# Altium schematic sheet Speaker_Switches.SchDoc
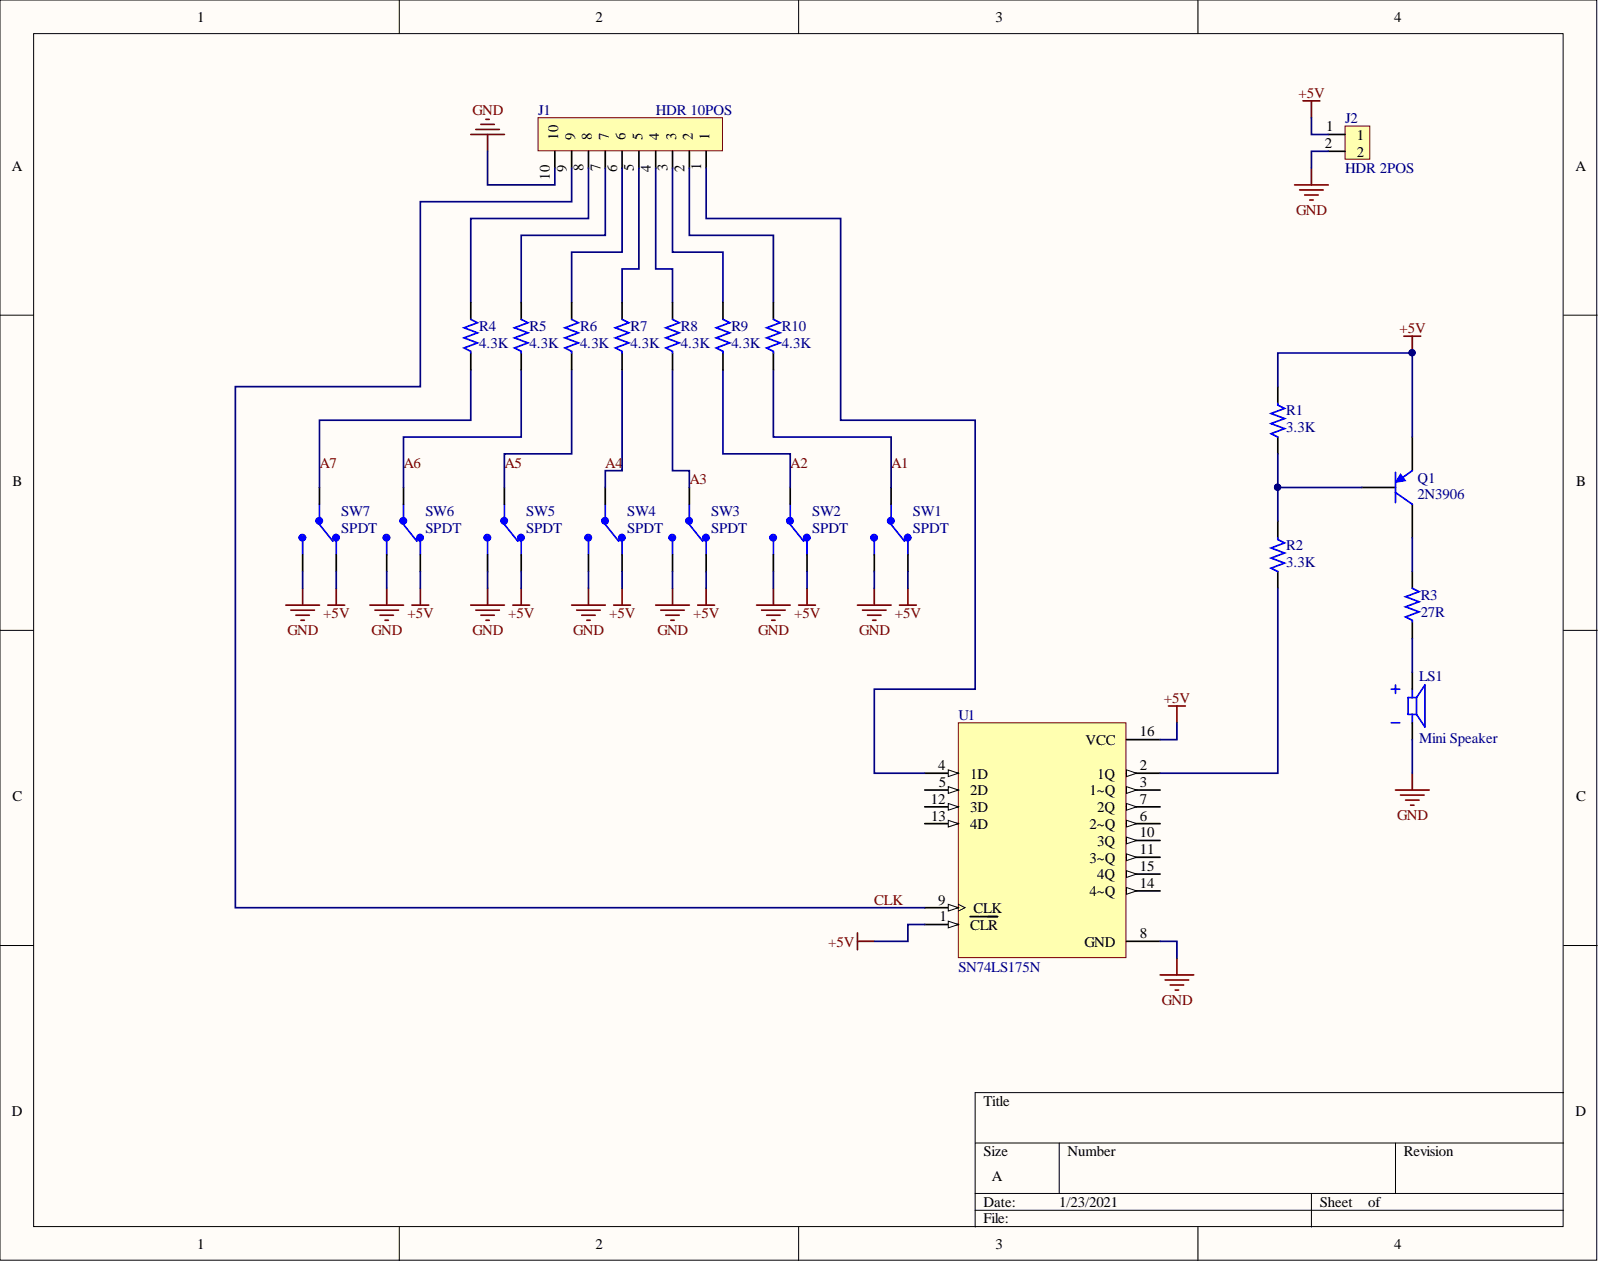
[diagram p0: Speaker_Switches.SchDoc]

## Parts
J1  HDR 10POS  [HDR 10 POS 2.54 FEMALE ST]
J2  HDR 2POS  [HDR 2 POS 2.54 Male ST]
LS1  Mini Speaker  [Speaker  Adafruit 8 Ohm 0.2W TH 1898 30mm 15mm]
Q1  2N3906  [TO-92A]
R1  3.3K  [Res TH 460mil 11.68mm]
R2  3.3K  [Res TH 460mil 11.68mm]
R3  27R  [Res TH 460mil 11.68mm]
R4  4.3K  [Res TH 460mil 11.68mm]
R5  4.3K  [Res TH 460mil 11.68mm]
R6  4.3K  [Res TH 460mil 11.68mm]
R7  4.3K  [Res TH 460mil 11.68mm]
R8  4.3K  [Res TH 460mil 11.68mm]
R9  4.3K  [Res TH 460mil 11.68mm]
R10  4.3K  [Res TH 460mil 11.68mm]
SW1  SPDT  [SW_1218_EWI]
SW2  SPDT  [SW_1218_EWI]
SW3  SPDT  [SW_1218_EWI]
SW4  SPDT  [SW_1218_EWI]
SW5  SPDT  [SW_1218_EWI]
SW6  SPDT  [SW_1218_EWI]
SW7  SPDT  [SW_1218_EWI]
U1  SN74LS175N  [DIP794W45P254L1969H508Q16]

## Nets
+5V: J2.1 Q1.1 R1.2 SW1.1 SW2.1 SW3.1 SW4.1 SW5.1 SW6.1 SW7.1 U1.1 U1.16
GND: J1.10 J2.2 LS1.N SW1.3 SW2.3 SW3.3 SW4.3 SW5.3 SW6.3 SW7.3 U1.8
A1: R10.2 SW1.2
A2: R9.2 SW2.2
A3: R8.2 SW3.2
A4: R7.2 SW4.2
A5: R6.2 SW5.2
A6: R5.2 SW6.2
A7: R4.2 SW7.2
CLK: J1.9 U1.9
NetJ1_1: J1.1 U1.4
NetJ1_2: J1.2 R10.1
NetJ1_3: J1.3 R9.1
NetJ1_4: J1.4 R8.1
NetJ1_5: J1.5 R7.1
NetJ1_6: J1.6 R6.1
NetJ1_7: J1.7 R5.1
NetJ1_8: J1.8 R4.1
NetLS1_P: LS1.P R3.2
NetQ1_2: Q1.2 R1.1 R2.2
NetQ1_3: Q1.3 R3.1
NetR2_1: R2.1 U1.2

Not connected: U1 3 5 6 7 10 11 12 13 14 15

## Library data of the parts
J1 = HDR 10 Pos 2.54mm female TH ST: Manufacturer Part Number 1: 90147-1110; Brand: Molex; Category 1: Headers & Wire Housings; Current Rating: 3 A; Description 1: Headers & Wire Housings C-Grid PCB Conn SR V onn SR Vt Tn-A 10Ckt; Mounting Angle: Vertical; Number of Positions: 10 Position; Voltage Rating: 350 V; Accessory Type: -; Component Kind: Standard; ComponentLink1Description: https://www.mouser.com/datasheet/2/276/1/0901471110_PCB_RECEPTACLES-176767.pdf; ComponentLink1URL: https://www.mouser.com/datasheet/2/276/1/0901471110_PCB_RECEPTACLES-176767.pdf; Contact Gender: Socket (Female); Contact Material: Tin; Contact Plating: Tin; Contact Type: -; Description: HDR 10 Pos 2.54mm female TH ST; Flammability Rating: UL 94 V-0; Housing Color: Black; Housing Gender: -; Housing Material: Polyester; Library Name: Schematic_Library.SchLib; Manufacturer 1: Molex; Maximum Operating Temperature: + 105 C; Minimum Operating Temperature: - 55 C; Minimum Order Quantity: 1; Mounting Style: Through Hole; Number of Rows: 1 Row; Packaging: Tube; Pitch: 2.54 mm; Pricing 1: 1=2.53, 10=2.06, 100=1.97, 250=1.78, 500=1.64, 1000=1.36, 2500=1.31, 5000=1.25 (USD); Product: Headers; Product Type: Headers & Wire Housings; Reeling: False; RoHS 1: RoHS Compliant; RoHS - Mouser: Y; Row Spacing: -; Series: 90147; Standard Pack Qty: 1760; Stock 1: 1869; Subcategory: Headers & Wire Housings; Supplier 1: Mouser; Supplier Part Number 1: 538-90147-1110; Termination Style: Through Hole; Tradename: C-Grid; Type: Socket
J2 = HDR 2 pos 2.54mm TH male Straigt: Manufacturer Part Number 1: 61300211121; Brand: Wurth Elektronik; Category 1: Headers & Wire Housings; Current Rating: 3 A; Description 1: Headers & Wire Housings WR-PHD 2.54mm 2Pin THT Header; Insulation Resistance: 1 GOhms; Latching Type: Unlatched; Mating Post Length: 6 mm; Mounting Angle: Straight; Number of Positions: 2 Position; Voltage Rating: 250 V; Accessory Type: -; Application: Wire to Board; Component Kind: Standard; ComponentLink1Description: https://www.mouser.com/datasheet/2/445/61300211121-1717910.pdf; ComponentLink1URL: https://www.mouser.com/datasheet/2/445/61300211121-1717910.pdf; Contact Gender: Pin (Male); Contact Material: Copper Alloy; Contact Plating: Gold; Contact Type: -; Description: HDR 2 pos 2.54mm TH male Straigt; Flammability Rating: UL 94 V-0; Housing Color: Black; Housing Gender: -; Housing Material: Polybutylene Terephthalate (PBT); Library Name: Schematic_Library.SchLib; Manufacturer 1: Wurth Elektronik; Maximum Operating Temperature: + 125 C; Minimum Operating Temperature: - 40 C; Minimum Order Quantity: 1; Mounting Style: Straight Pin; Number of Rows: 1 Row; Packaging: Bulk; Pitch: 2.54 mm; Pricing 1: 1=0.120, 10=0.118, 25=0.116, 50=0.113, 100=0.106, 250=0.104, 500=0.090, 1000=0.089 (USD); Product: Headers; Product Type: Headers & Wire Housings; Reeling: False; RoHS 1: RoHS Compliant; RoHS - Mouser: Y; Row Spacing: -; Series: WR-PHD; Standard Pack Qty: 1000; Stock 1: 32934; Subcategory: Headers & Wire Housings; Supplier 1: Mouser; Supplier Part Number 1: 710-61300211121; Termination Post Length: 3 mm; Termination Style: Through Hole; Type: Pin Strip
LS1 = Speaker - 8 Ohm 0.2W Breadboard-Friendly PCB Mount Mini Speaker TH: Breadboard-Friendly PCB Mount Mini Speaker - 8 Ohm 0.2W adafruit 1898 KS-3008; Component Kind: Standard; Library Name: Schematic_Library.SchLib; Supplier 1: Adafruit; Supplier Part Number 1: 1898
Q1 = 2N3906: PNP General Purpose Amplifier; Component Kind: Standard; ComponentLink1Description: Manufacturer Link; ComponentLink1URL: http://www.fairchildsemi.com/; ComponentLink2Description: Datasheet; ComponentLink2URL: http://www.fairchildsemi.com/ds/2N%2F2N3906.pdf; DatasheetVersion: 1997; LatestRevisionDate: 15-Jan-2003; LatestRevisionNote: PCB Footprint 'BCY-W3/D4.7' replaced; Library Name: Miscellaneous Devices.IntLib; PackageDescription: TO, Flat Index; 3 In-Line, Axial Leads; Body Dia. 4.5mm; Leads 0.51 x 0.48 mm (max); PackageReference: TO-92A; PackageVersion: Sep-1998; Published: 8-Jun-2000; Signal Integrity: 2N3906; Simulation: 2N3906; Publisher: Altium Limited
R1 R2 = Res 3.3K TH 5% 1/4W 460" pitch: Res 3.3K TH 5% 1/4W; Manufacturer: Yageo; Manufacturer Part Number: CFR-25JB-52-3K3; Catalog Drawings: Carbon Film Resistor    Derating Curve; Kits: RS125-ND  - KIT RES 1.0-1.0M 1/4W 73 VALUES  RSQ200-ND  - KIT RES 1/4W 5% 200PCS 169VALUES; Online Catalog: CFR Series; Other Names: 3.3KQ  3.3KQBK  3K3 CR-1/4W-B 5%  CFR-25JB  3K3  CFR-25JB-3K3  CFR-25JB-523K3  CFR25JB523K3  CR-25-B-3.3K; Power (Watts): 350ppm/°C; Product Training Modules: Leaded Resistors; Temperature Coefficient: Axial; Tolerance: 0.094" Dia x 0.248" L (2.40mm x 6.30mm); Unit PriceUSD: CFR; Category: Resistors; Component Kind: Standard; ComponentLink1Description: http://components.arrow.com/part/dataview/0323_05936959?src-supplier=Arrow+Europe; ComponentLink1URL: http://components.arrow.com/part/dataview/0323_05936959?src-supplier=Arrow+Europe; ComponentLink2Description: http://www.yageo.com/NewPortal/yageodocoutput?fileName=/pdf/throughhole/Yageo_LR_CFR_2013.pdf&src-supplier=Digi-Key; ComponentLink2URL: http://www.yageo.com/NewPortal/yageodocoutput?fileName=/pdf/throughhole/Yageo_LR_CFR_2013.pdf&src-supplier=Digi-Key; Composition: ±5%; Family: Through Hole Resistors; Features: Axial; Library Name: Schematic_Library.SchLib; Minimum Quantity: 3.3k; Number of Terminations: 2; Operating Temperature: -55°C ~ 155°C; Package / Case: Axial; Packaging: 0.25W, 1/4W; Pricing 1: 1=0.1, 25=0.0464, 50=0.036, 100=0.0258, 250=0.0201, 500=0.016, 750=0.0137, 1000=0.0113, 2000=0.0098 (USD); Quantity Available: BulkAlternate Packaging; Resistance (Ohms): 3.3k; Series: Carbon Film; Size / Dimension: 0.094" Dia x 0.248" L (2.40mm x 6.30mm); Standard Package: 200; Stock 1: 47211; Supplier 1: Digi-Key; Supplier Device Package: Axial; Supplier Part Number 1: 3.3KQBK-ND
R3 = Res 27R TH 5% 1/4W 460" pitch: Res 27K TH 5% 1/4W; Manufacturer: Yageo; Manufacturer Part Number: CFR-25JB-52-27R; Catalog Drawings: Carbon Film Resistor    Derating Curve; Kits: RS125-ND  - KIT RES 1.0-1.0M 1/4W 73 VALUES  RSQ200-ND  - KIT RES 1/4W 5% 200PCS 169VALUES; Minimum Quantity: 27; Online Catalog: CFR Series; Other Names: 27E CR-1/4W-B 5%  27Q  27QBK  CFR-25JB  27R  CFR-25JB-27R  CFR-25JB-5227R  CFR25JB5227R  CR-25-B-27; Power (Watts): 350ppm/°C; Product Training Modules: Leaded Resistors; Temperature Coefficient: Axial; Tolerance: 0.094" Dia x 0.248" L (2.40mm x 6.30mm); Unit PriceUSD: CFR; Category: Resistors; Component Kind: Standard; ComponentLink1Description: http://www.yageo.com/NewPortal/yageodocoutput?fileName=/pdf/throughhole/Yageo_LR_CFR_2013.pdf&src-supplier=Digi-Key; ComponentLink1URL: http://www.yageo.com/NewPortal/yageodocoutput?fileName=/pdf/throughhole/Yageo_LR_CFR_2013.pdf&src-supplier=Digi-Key; Composition: ±5%; Family: Through Hole Resistors; Features: Axial; Library Name: Schematic_Library.SchLib; Number of Terminations: 2; Operating Temperature: -55°C ~ 155°C; Package / Case: Axial; Packaging: 0.25W, 1/4W; Pricing 1: 1=0.1, 25=0.0464, 50=0.036, 100=0.0258, 250=0.0201, 500=0.016, 750=0.0137, 1000=0.0113, 2000=0.0098 (USD); Quantity Available: BulkAlternate Packaging; Resistance (Ohms): 27; Series: Carbon Film; Size / Dimension: 0.094" Dia x 0.248" L (2.40mm x 6.30mm); Standard Package: 200; Stock 1: 38106; Supplier 1: Digi-Key; Supplier Device Package: Axial; Supplier Part Number 1: 27QBK-ND
R4-R10 = Res 4.3K TH 5% 1/4W 460" pitch: RES 4.3K OHM 1/4W 5% AXIAL; Manufacturer: Yageo; Manufacturer Part Number: CFR-25JB-52-4K3; Catalog Drawings: Carbon Film Resistor    Derating Curve; Kits: RS225-ND  - KIT RES 1.1-910K 1/4W 72 VALUES  RSQ200-ND  - KIT RES 1/4W 5% 200PCS 169VALUES; Online Catalog: CFR Series; Other Names: 4.3KQ  4.3KQBK  4K3 CR-1/4W-B 5%  CFR-25JB  4K3  CFR-25JB-4K3  CFR-25JB-524K3  CFR25JB524K3  CR-25-B-4.3K; Power (Watts): 350ppm/°C; Product Training Modules: Leaded Resistors; Tolerance: 0.094" Dia x 0.248" L (2.40mm x 6.30mm); Unit PriceUSD: CFR; Category: Resistors; Component Kind: Standard; ComponentLink1Description: http://www.yageo.com/NewPortal/yageodocoutput?fileName=/pdf/throughhole/Yageo_LR_CFR_2013.pdf&src-supplier=Digi-Key; ComponentLink1URL: http://www.yageo.com/NewPortal/yageodocoutput?fileName=/pdf/throughhole/Yageo_LR_CFR_2013.pdf&src-supplier=Digi-Key; Composition: ±5%; Family: Through Hole Resistors; Features: Axial; Library Name: Schematic_Library.SchLib; Minimum Quantity: 4.3k; Number of Terminations: 2; Operating Temperature: -55°C ~ 155°C; Package / Case: Axial; Packaging: 0.25W, 1/4W; Pricing 1: 1=0.1, 10=0.067, 25=0.0464, 50=0.036, 100=0.0258, 250=0.0201, 500=0.016, 1000=0.0113, 5000=0.0088 (USD); Quantity Available: BulkAlternate Packaging; Resistance (Ohms): 4.3k; Series: Carbon Film; Size / Dimension: 0.094" Dia x 0.248" L (2.40mm x 6.30mm); Standard Package: 200; Stock 1: 10070; Supplier 1: Digi-Key; Supplier Device Package: Axial; Supplier Part Number 1: 4.3KQBK-ND; Temperature Coefficient: Axial
SW1-SW7 = Slide Switch SPDT 30V 200mA TH ST: SWITCH SLIDE SPDT 200MA 30V; Manufacturer: E-Switch; 3D Model: EG1218.stp; Actuator Length: 2.00mm; Actuator Type: Standard; Catalog Drawings: EG1218; Contact Timing: Non-Shorting (BBM); Current Rating: 200mA (DC); Online Catalog: EG Series; Operating Force: 250gf; Other Names: EG1903 P040040; Product Training Modules: Switch Basics Power Switch Overview; Switch Function: On-On; Switch Travel: 2.50mm; Actuator Material: -; Category: Switches; Circuit: SPDT; Component Kind: Standard; ComponentLink1Description: http://spec.e-switch.com/P-J/P040040C.pdf?src-supplier=Newark; ComponentLink1URL: http://spec.e-switch.com/P-J/P040040C.pdf?src-supplier=Newark; Contact Finish: Silver; Contact Material: Copper Alloy; Electrical Life: -; Family: Slide Switches; Features: -; Library Name: Schematic_Library.SchLib; Manufacturer Part Number: EG1218; Mechanical Life: -; Mounting Type: Through Hole; Operating Temperature: -20°C ~ 70°C; Packaging: Bulk; Pricing 1: 1=0.65, 10=0.627, 25=0.6024, 100=0.523, 250=0.4772, 500=0.4434, 1000=0.3977, 2500=0.3736, 5000=0.347 (USD); Series: EG; Standard Package: 1,000; Stock 1: 36403; Supplier 1: Digi-Key; Supplier Part Number 1: EG1903-ND; Termination Style: PC Pin; Voltage Rating - AC: -; Voltage Rating - DC: 30V
U1 = SN74LS175N Flip Flop 1 Element D-Type 4 Bit Positive Edge 16-DIP (0.300, 7.62mm): Flip Flop High Speed Quadruple D-Type; Manufacturer: Texas Instruments; Bus Hold: No; Input Signal Type: Single-Ended; Logic Family: LS; Logic Function: D-Type Bus Interface; Number of Channels per Chip: 4; Number of Elements per Chip: 1; Number of Output Enables per Element: 0; Output Signal Type: Differential; Set/Reset: Master Reset; Typical Operating Supply Voltage: 5 V; Category: Flip Flop; Component Kind: Standard; ComponentLink1Description: http://www.ti.com/lit/ds/symlink/sn74ls175.pdf?src-supplier=Digi-Key; ComponentLink1URL: http://www.ti.com/lit/ds/symlink/sn74ls175.pdf?src-supplier=Digi-Key; ComponentLink2Description: http://www.ti.com/general/docs/lit/getliterature.tsp?genericPartNumber=SN54S175&&fileType=pdf&src-supplier=Avnet; ComponentLink2URL: http://www.ti.com/general/docs/lit/getliterature.tsp?genericPartNumber=SN54S175&&fileType=pdf&src-supplier=Avnet; ComponentLink3Description: http://www.farnell.com/datasheets/1967584.pdf?src-supplier=Element14; ComponentLink3URL: http://www.farnell.com/datasheets/1967584.pdf?src-supplier=Element14; ComponentLink4Description: https://www.distrelec.biz/Web/Downloads/56/13/kf645613.pdf?mime=application%2Fpdf&src-supplier=Distrelec; ComponentLink4URL: https://www.distrelec.biz/Web/Downloads/56/13/kf645613.pdf?mime=application%2Fpdf&src-supplier=Distrelec; ComponentLink5Description: https://www.verical.com/server-webapp/html/getDatasheet?partID=250178&src-supplier=Verical; ComponentLink5URL: https://www.verical.com/server-webapp/html/getDatasheet?partID=250178&src-supplier=Verical; Library Name: Schematic_Library.SchLib; Manufacturer Part Number: SN74LS175N; Number of Element Inputs: 4; Number of Element Outputs: 4; Operating Temperature: 0 to 70 °C; Package: 16PDIP; Packaging: Rail / Tube; Pricing 1: 1=0.93, 10=0.818, 25=0.7688, 100=0.6274, 250=0.5828, 500=0.496, 1000=0.3968, 2500=0.3596, 5000=0.3348 (USD); Stock 1: 2035; Supplier 1: Digi-Key; Supplier Part Number 1: 296-1648-5-ND
